# Altium schematic sheet Connectors.SchDoc
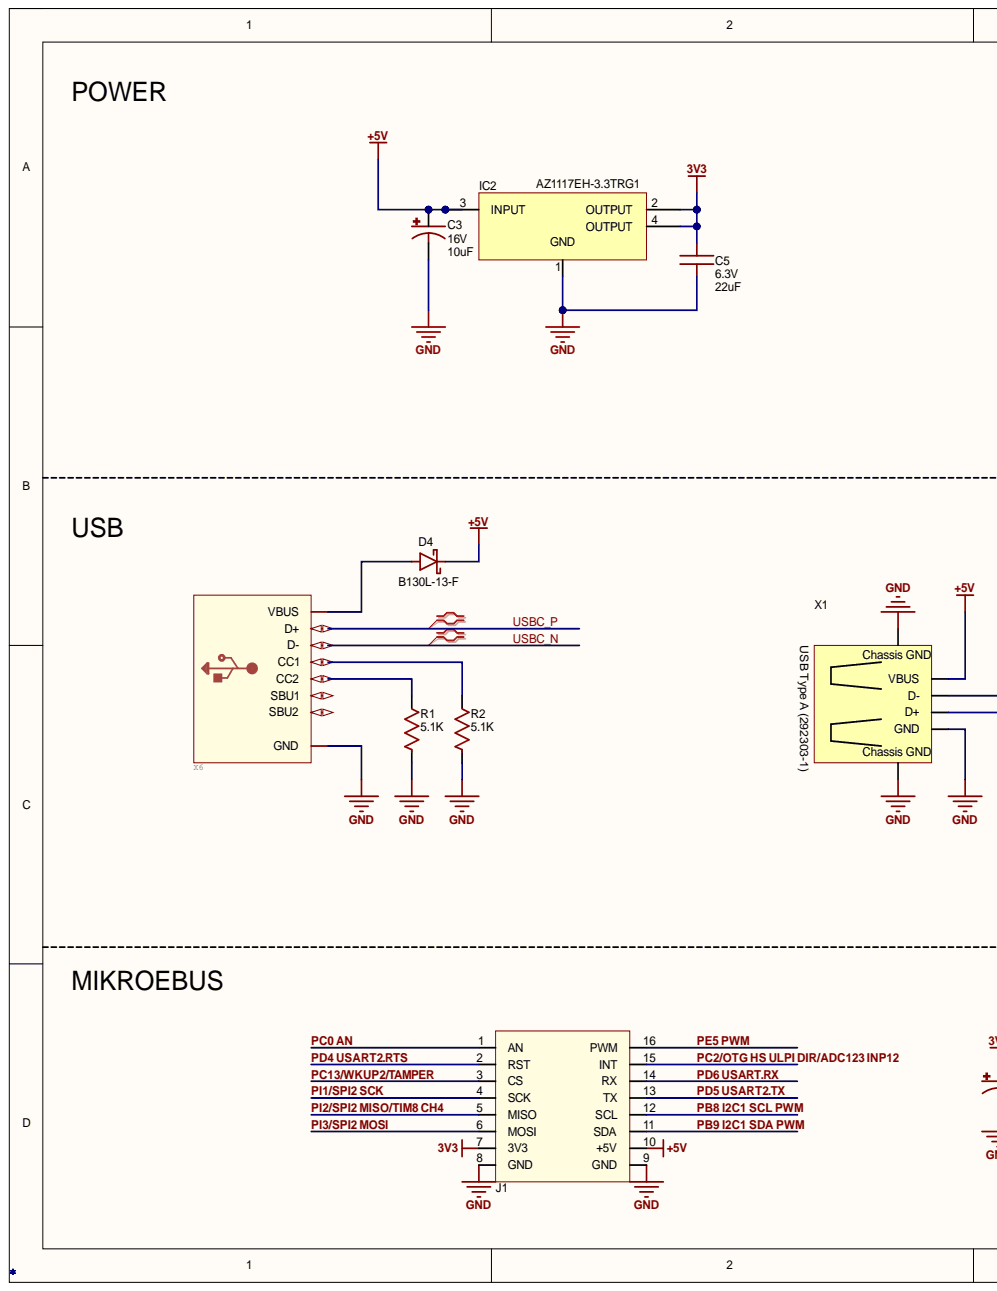
[diagram p0: Connectors.SchDoc, left]
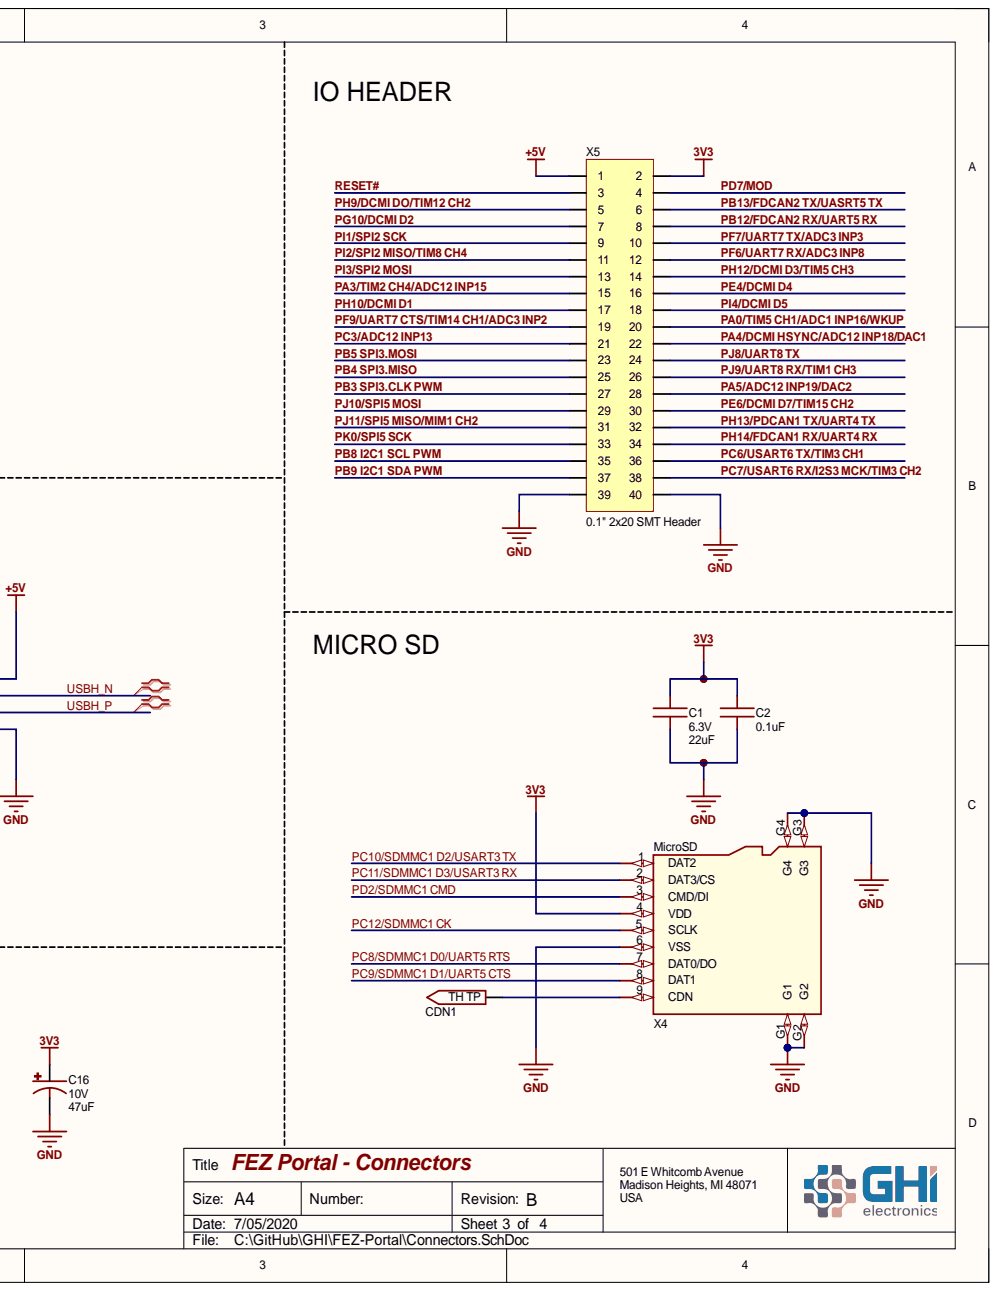
[diagram p1: Connectors.SchDoc, right]

## Parts
C1  value 22uF  Capacitance: 22uF  Voltage: 6.3V  [C0805]  (hidden comment: 0805 Cap 22uF)
C2  value 0.1uF  Capacitance: 0.1uF  [C0603 (1608 Metric)]  (hidden comment: 0603 Cap 0.1uF)
C3  value 10uF  Capacitance: 10uF  Voltage: 16V  [CASE-B (3528 METRIC)]  (hidden comment: CASE-B  3528 Tant 10uF)
C5  value 22uF  Capacitance: 22uF  Voltage: 6.3V  [C0805]  (hidden comment: 0805 Cap 22uF)
C16  value 47uF  Capacitance: 47uF  Voltage: 10V  [CASE-B (3528 METRIC)]  (hidden comment: CASE-B  Tant 47uF)
CDN1  TH Testpad 32mil (32mil ar)  [32mil TH]  (value not drawn)  (no BOM)
D4  B130L-13-F  [SMA]
IC2  AZ1117EH-3.3TRG1  [SOT223]
J1  mikroBUS SMT  [mikroBus - SMT]  (value not drawn)
R1  value 5.1K  Resistance: 5.1K  [R0402]  (hidden comment: 0402 Res 5.1K)
R2  value 5.1K  Resistance: 5.1K  [R0402]  (hidden comment: 0402 Res 5.1K)
X1  USB Type A (292303-1)  [AMP 292303-1]
X4  MICROSD  [MICROSD]
X5  0.1" 2x20 SMT Header  [SMT 0.1" 2x20]
X6  (no value)  [GCT_USB4105_revA]

## Nets
3V3: C1.2 C2.2 C5.2 C16.+ IC2.2(OUTPUT) IC2.4(OUTPUT) J1.7(3V3) X4.4(VDD) X5.2
+5V: C3.+ D4.K IC2.3(INPUT) J1.10(+5V) X1.1(VBUS) X5.1
GND: C1.1 C2.1 C3.- C5.1 C16.- IC2.1 J1.8(GND) J1.9(GND) R1.1 R2.1 X1.4(GND) X1.CG1 X1.CG2 X4.6(VSS) X4.G1 X4.G2 X4.G3 X4.G4 X5.39 X5.40 X6.GND
PA0/TIM5 CH1/ADC1 INP16/WKUP: X5.20
PA3/TIM2 CH4/ADC12 INP15: X5.15
PA4/DCMI HSYNC/ADC12 INP18/DAC1: X5.22
PA5/ADC12 INP19/DAC2: X5.28
PB3 SPI3.CLK PWM: X5.27
PB4 SPI3.MISO: X5.25
PB5 SPI3.MOSI: X5.23
PB8 I2C1 SCL PWM: J1.12(SCL) X5.35
PB9 I2C1 SDA PWM: J1.11(SDA) X5.37
PB12/FDCAN2 RX/UART5 RX: X5.8
PB13/FDCAN2 TX/UASRT5 TX: X5.6
PC0 AN: J1.1(AN)
PC2/OTG HS ULPI DIR/ADC123 INP12: J1.15(INT)
PC3/ADC12 INP13: X5.21
PC6/USART6 TX/TIM3 CH1: X5.36
PC7/USART6 RX/I2S3 MCK/TIM3 CH2: X5.38
PC8/SDMMC1 D0/UART5 RTS: X4.7(DAT0/DO)
PC9/SDMMC1 D1/UART5 CTS: X4.8(DAT1)
PC10/SDMMC1 D2/USART3 TX: X4.1(DAT2)
PC11/SDMMC1 D3/USART3 RX: X4.2(DAT3/CS)
PC12/SDMMC1 CK: X4.5(SCLK)
PC13/WKUP2/TAMPER: J1.3(CS)
PD2/SDMMC1 CMD: X4.3(CMD/DI)
PD4 USART2.RTS: J1.2(RST)
PD5 USART2.TX: J1.13(TX)
PD6 USART.RX: J1.14(RX)
PD7/MOD: X5.4
PE4/DCMI D4: X5.16
PE5 PWM: J1.16(PWM)
PE6/DCMI D7/TIM15 CH2: X5.30
PF6/UART7 RX/ADC3 INP8: X5.12
PF7/UART7 TX/ADC3 INP3: X5.10
PF9/UART7 CTS/TIM14 CH1/ADC3 INP2: X5.19
PG10/DCMI D2: X5.7
PH9/DCMI DO/TIM12 CH2: X5.5
PH10/DCMI D1: X5.17
PH12/DCMI D3/TIM5 CH3: X5.14
PH13/PDCAN1 TX/UART4 TX: X5.32
PH14/FDCAN1 RX/UART4 RX: X5.34
PI1/SPI2 SCK: J1.4(SCK) X5.9
PI2/SPI2 MISO/TIM8 CH4: J1.5(MISO) X5.11
PI3/SPI2 MOSI: J1.6(MOSI) X5.13
PI4/DCMI D5: X5.18
PJ8/UART8 TX: X5.24
PJ9/UART8 RX/TIM1 CH3: X5.26
PJ10/SPI5 MOSI: X5.29
PJ11/SPI5 MISO/MIM1 CH2: X5.31
PK0/SPI5 SCK: X5.33
RESET#: X5.3
USBC_N: X6.A7B7(D-)
USBC_P: X6.A6B6(D+)
USBH_N: X1.2(D-)
USBH_P: X1.3(D+)
NetCDN1_1: CDN1.1 X4.9(CDN)
NetD4_A: D4.A X6.A4B9(VBUS)
NetR1_2: R1.2 X6.B5(CC2)
NetR2_2: R2.2 X6.A5(CC1)

Not connected: X6 A8(SBU1) B8(SBU2)

## Library data of the parts
C1 C5 = 0805 Cap 22uF: 22uF ±20% 6.3V X5R 0805 Multilayer Ceramic Capacitors MLCC - SMD/SMT RoHS; Mfr.Part #: CL21A226MQCLRNC; Category: Ceramic; Component Kind: Standard; LCSC Part #: C307530; Package: 0805; Tolerance: ±20%; Library Name: GHI-Passives.SchLib
C2 = 0603 Cap 0.1uF: 0.1uF ±5% 50V X7R 0603 Multilayer Ceramic Capacitors MLCC - SMD/SMT RoHS; Mfr.Part #: CL10B104JB8NNNC; Category: Ceramic; Component Kind: Standard; Digikey: 1276-1033-1-ND; LCSC Part #: C24452; Package: 0603; Tolerance: ±5%; Voltage: 50V; Library Name: GHI-Passives.SchLib
C3 = CASE-B Tant 10uF: 10uF ±10% 16V 800 mΩ @ 100kHz -55℃ ~ +125℃ CASE-B_3528 Tantalum Capacitors RoHS; Mfr.Part #: TPSB106K016R0800; Category: Tantalum; Component Kind: Standard; Digikey: 478-2398-1-ND; LCSC Part #: C273667; Package: CASE-B_3528; Tolerance: ±10%; Library Name: GHI-Passives.SchLib
C16 = CASE-B  Tant 47uF: 47uF ±10% 10V 1 Ω @ 100kHz -55℃ ~ +125℃ CASE-B_3528 Tantalum Capacitors RoHS; Mfr.Part #: TAJB476K010RNJ; Category: Tantalum; Component Kind: Standard; LCSC Part #: C22036; Package: CASE-B 3528; Tolerance: ±10%; Library Name: GHI-Passives.SchLib
CDN1 = TH Testpad 32mil (32mil ar): TH Testpad 32mil (32mil annular ring); Library Name: GHI.SchLib
D4 = B130L-13-F 1A Schottky SMA: 30V 1A 410mV @ 1A SMA(DO-214AC) Schottky Barrier Diodes (SBD) RoHS; GHI Part Number: EPC-DB130L-13; Manufacturer: Diodes Incorporated; Component Kind: Standard; Component Type: Diode; Library Name: GHI.SchLib; Mfr.Part #: B130L-13-F; Package: SMA(DO-214AC); Value: B130L-13-F
IC2 = AZ1117EH-3.3TRG1: Fixed 13V 3.3V 1A SOT-223 Low Dropout Regulators(LDO) RoHS; Manufacturer: Diodes Incorporated; Component Kind: Standard; LCSC Part #: C108494; Mfr.Part #: AZ1117EH-3.3TRG1; Package: SOT-223; Value: AZ1117EH-3.3TRG1; Library Name: GHI-Power.SchLib
J1 = mikroBUS SMT: PartNo: 218108M141; Value: 218108M141; Component Kind: Standard; Library Name: GHI.SchLib
R1 R2 = 0402 Res 5.1K: 5.1K ±1% 1/16W ±100ppm/℃ 0402 Chip Resistor - Surface Mount RoHS; Manufacturer: YAGEO; Mfr.Part #: RC0402FR-075K1L; Voltage Rating: *V; Component Kind: Standard; LCSC Part #: C105872; Package: 0402; Power Rating: 1/16W; Resistance Tolerance: ±1%; Library Name: GHI-Passives.SchLib
X1 = USB Type A Receptacle: TE Connectivity 292303-1 USB Type A receptacle; Component Kind: Standard; PartNo: 292303-1; Value: 292303-1; Library Name: GHI Sch.SchLib
X5 = 2x20 Pin 0.1" SMT: Component Kind: Standard; Description: 2x20 Pin 0.1" SMT; Value: 2x20 Pin 0.1" SMT; Library Name: GHI.SchLib
X6 = USB - C: USB - C (Type - C) USB 2.0 Receptacle Connector 24 (16+8 Dummy) Position Surface Mount, Right Angle; Through Hole; Manufacturer: GCT; Mfr.Part #: USB4105-GF-A; Value: USB4105-GF-A; Component Kind: Standard; Digi-Key Part Number: 2073-USB4105-GF-ATR-ND; LCSC Part #: C319148; Library Name: GHI-Connectors.SchLib
X4 = MICROSD: Component Kind: Standard; PartNo: MicroSD; Value: MicroSD; Library Name: GHI.SchLib
